# Altium schematic sheet Counter_PickUp_v2.SchDoc
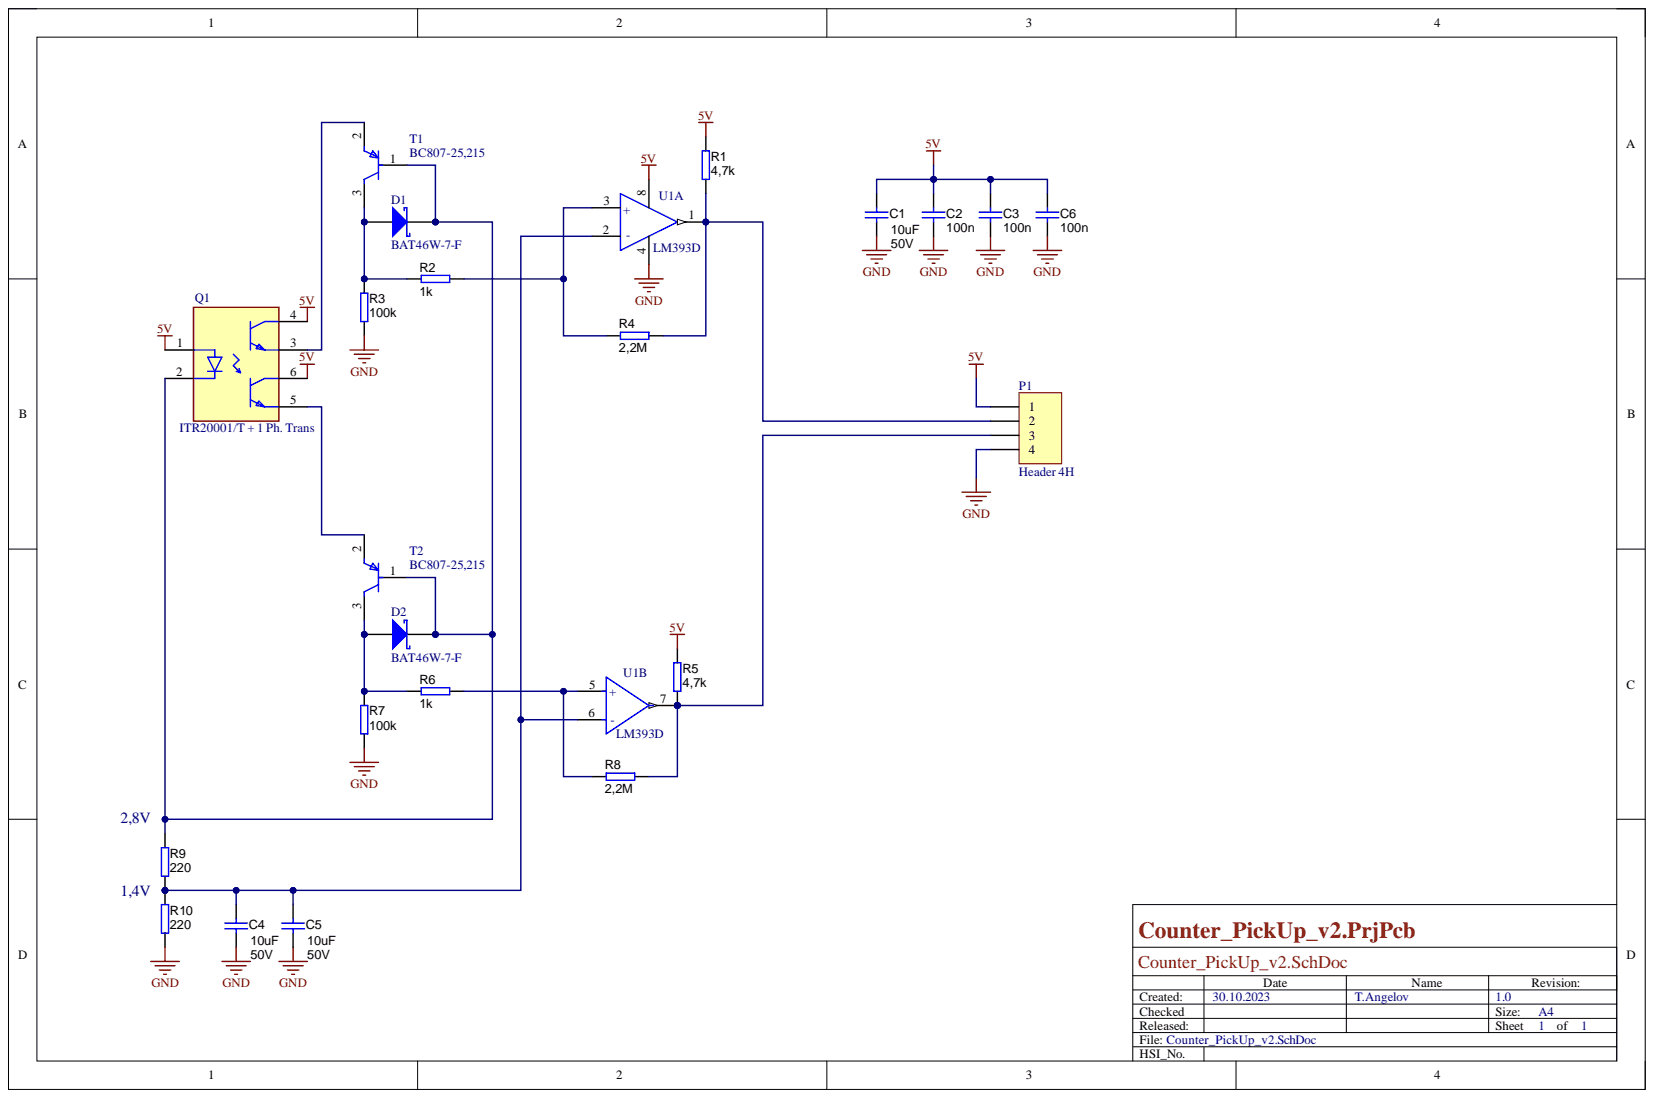
[diagram p0: Counter_PickUp_v2.SchDoc]

## Parts
C1  value 10uF  Value1: 50V  [1206_180]
C2  value 100n  [0805_HV]
C3  value 100n  [0805_HV]
C4  value 10uF  Value1: 50V  [1206_180]
C5  value 10uF  Value1: 50V  [1206_180]
C6  value 100n  [0805_HV]
D1  BAT46W-7-F  [SOD-123-2_V]
D2  BAT46W-7-F  [SOD-123-2_V]
P1  Header 4H  [HDR1X4H]
Q1  Photo_T  [Photo_T]  (value not drawn)
R1  value 4,7k  [0805_HV]
R2  value 1k  [0805_HV]
R3  value 100k  [0805_HV]
R4  value 2,2M  [0805_HV]
R5  value 4,7k  [0805_HV]
R6  value 1k  [0805_HV]
R7  value 100k  [0805_HV]
R8  value 2,2M  [0805_HV]
R9  value 220  [0805_HV]
R10  value 220  [0805_HV]
T1  BC807-25,215  [SOT23_0]
T2  BC807-25,215  [SOT23_0]
U1  LM393D  [SO8_IPC_A]  (parts A,B)

## Nets
5V: C1.1 C2.2 C3.2 C6.2 P1.1 Q1.1 Q1.4 Q1.6 R1.1 R5.1 U1.8
GND: C1.2 C2.1 C3.1 C4.2 C5.2 C6.1 P1.4 R3.2 R7.2 R10.1 U1.4
NetC4_1: C4.1 C5.1 R9.1 R10.2 U1.2(-) U1.6(-)
NetD1_1: D1.1 R2.1 R3.1 T1.3
NetD1_2: D1.2 D2.2 Q1.2 R9.2 T1.1 T2.1
NetD2_1: D2.1 R6.1 R7.1 T2.3
NetP1_2: P1.2 R1.2 R4.1 U1.1
NetP1_3: P1.3 R5.2 R8.1 U1.7
NetQ1_3: Q1.3 T1.2
NetQ1_5: Q1.5 T2.2
NetR2_2: R2.2 R4.2 U1.3(+)
NetR6_2: R6.2 R8.2 U1.5(+)

## Library data of the parts
C1 C4 C5 = C1206: CAP, CERM, NP0, 1206; SizeArea_mm2: 5.12; SizeAreaWB_mm2: 10.92; SizeX_mm: 3.2; SizeY_mm: 1.6; SizeZ_mm: 1.8; TaMax_C: 125; TaMin_C: -55; TempCo: X7R; Tolerance_%: 10; Component Kind: Standard; MountType: SMT; PackageReference: 1206; Signal Integrity: Cap; Simulation: Cap; Technology: Ceramic; Library Name: Const_Cur_Source.SCHLIB
C2 C3 C6 = C0805: CAP, CERM, +/- 10%, X7S,  0805; CompType: Capacitor; SizeArea_mm2: 2.5; SizeAreaWB_mm2: 6.75; SizeX_mm: 2; SizeY_mm: 1.25; SizeZ_mm: 1.45; Tolerance_%: 10; Value1: 25V; Component Kind: Standard; MountType: SMT; PackageReference: 0805; Signal Integrity: Cap; Simulation: Cap; Technology: Ceramic; TempCo: X7S; Library Name: Const_Cur_Source.SCHLIB
D1 D2 = BAT46W-7-F: Surface Mount Schottky Barrier Diode, 100 V, -55 to 125 degC, 2-Pin SOD123, RoHS, Tape and Reel; Manufacturer: Diodes Inc; CT@1 V: 12 pF Typ.; IR@1.5 V: 0.3 uA Max.; V(BR)R: 100 V Min.; VF@10 mA: 0.45 V Max.; Component Kind: Standard; ComponentLink1Description: Manufacturer URL; ComponentLink1URL: http://www.diodes.com/; ComponentLink2Description: Datasheet; ComponentLink2URL: http://diodes.com/datasheets/ds30044.pdf; ComponentLink3Description: Package Specification; ComponentLink3URL: http://diodes.com/datasheets/ds30044.pdf; DatasheetVersion: Rev. 16-2; Mounting Technology: Surface Mount; Operating Temperature: -55 to 125 degC; PackageDescription: 2-Pin SMD, Body 2.7 x 1.55 mm, Height 1.45 mm; Packing: Tape and Reel; PartNumber: BAT46W-7-F; RoHS: TRUE; Library Name: Const_Cur_Source.SCHLIB
P1 = Header 4H: Header, 4-Pin, Right Angle; Component Kind: Standard; LatestRevisionDate: 17-Jul-2002; LatestRevisionNote: Re-released for DXP Platform.; Library Name: Miscellaneous Connectors.IntLib; Signal Integrity: Connector; Publisher: Altium Limited
R1-R10 = R0805: RES, 1%, 0.1 W, 0805; SizeX_mm: 2; SizeY_mm: 1.25; SizeZ_mm: 0.75; Component Kind: Standard; MountType: SMT; PackageReference: 0805; Signal Integrity: Resistor; Simulation: Resistor; Value1: 1%; Library Name: Const_Cur_Source.SCHLIB
Q1 = Photo_T: Component Kind: Standard; Library Name: Const_Cur_Source.SCHLIB
T1 T2 = BC807-25,215: Component Kind: Standard; Library Name: Const_Cur_Source.SCHLIB
U1 = LM393D: Component Kind: Standard; Library Name: Const_Cur_Source.SCHLIB
